# Altium schematic sheet TPS6120x.SchDoc(TPS6120x)
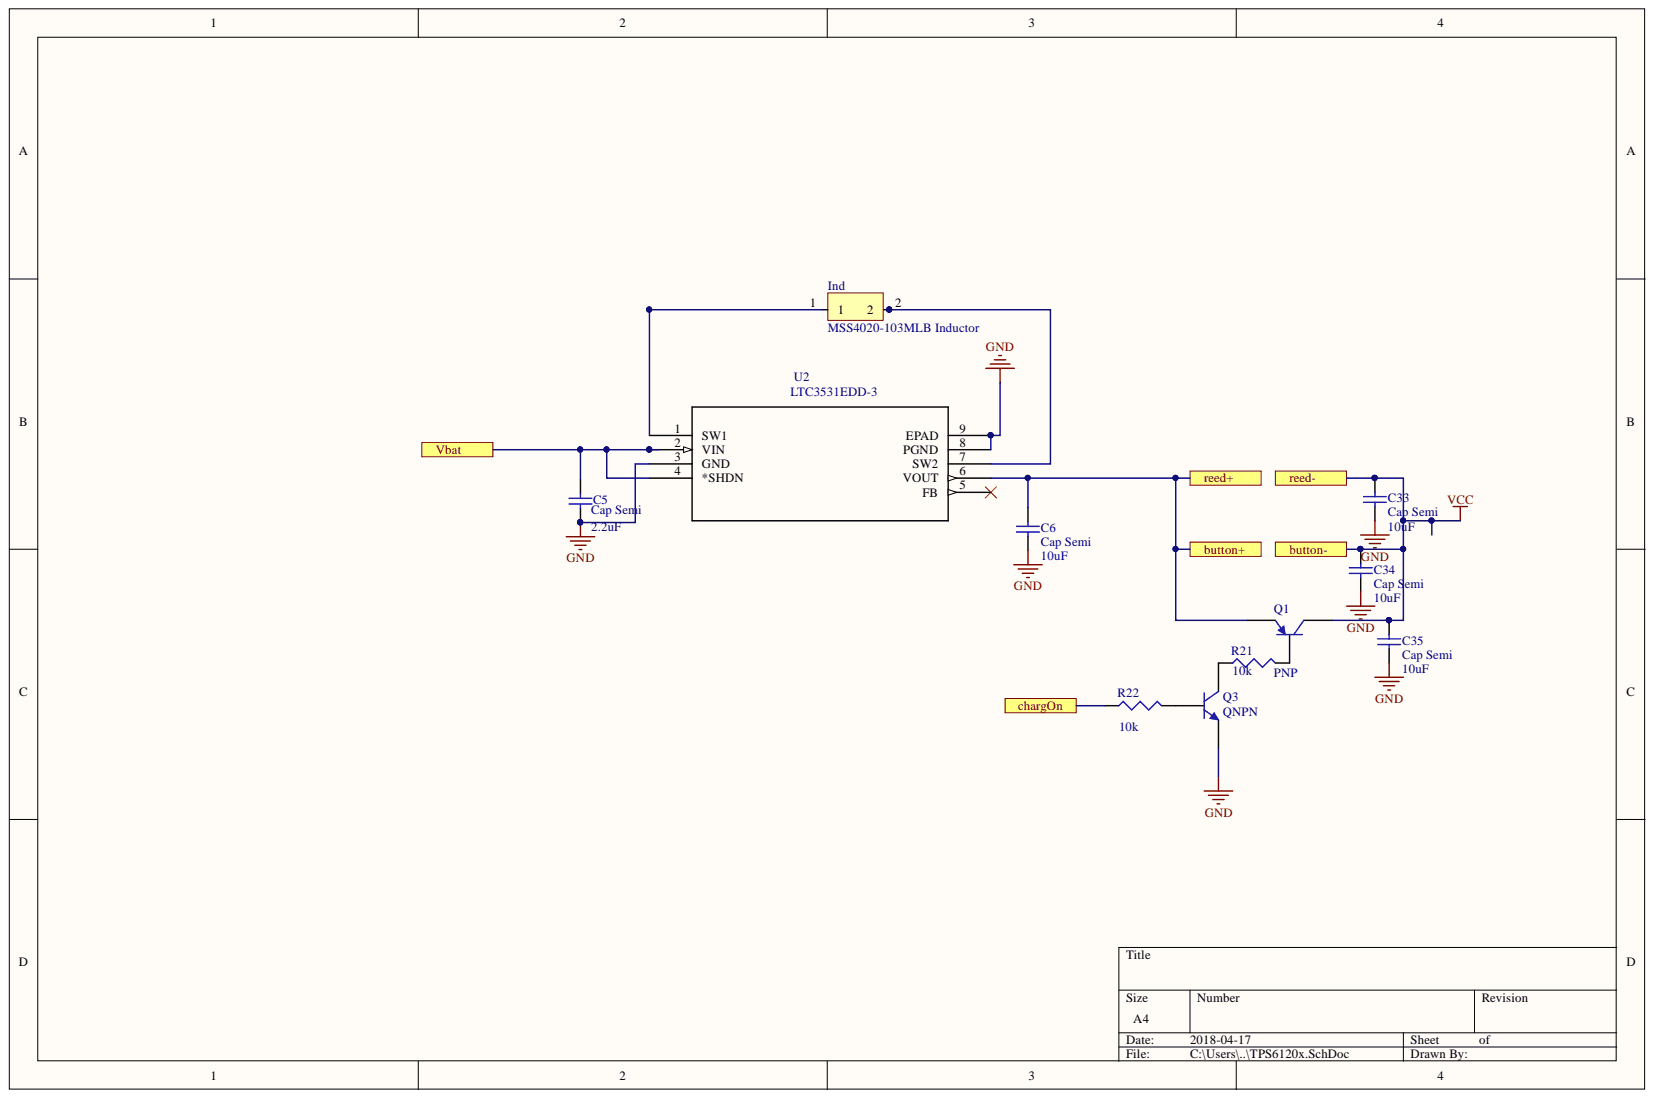
[diagram p0: TPS6120x.SchDoc]

## Parts
C5  Cap Semi  value 2.2uF  [1608[0603]]
C6  Cap Semi  value 10uF  [1608[0603]]
C33  Cap Semi  value 10uF  [1608[0603]]
C34  Cap Semi  value 10uF  [1608[0603]]
C35  Cap Semi  value 10uF  [1608[0603]]
Ind  MSS4020-103MLB Inductor  [MSS4020-103MLB]
Q1  PNP  [SOT-23B_N]
Q3  QNPN  [SOT-23B_N]
R21  value 10k  [J1-0603]  (hidden comment: Res3)
R22  value 10k  [J1-0603]  (hidden comment: Res3)
U2  LTC3531EDD-3  [LTC3531EDD-3]

## Ports
button+, button-, chargOn, reed+, reed-, Vbat

## Nets
GND: C5.1 C6.1 C33.1 C34.1 C35.1 Q3.3 U2.3(GND) U2.8(PGND) U2.9(EPAD)
VCC: C33.2 C34.2 C35.2 Q1.1
chargOn: R22.1 ; port chargOn
Vbat: C5.2 U2.2(VIN) U2.4(*SHDN) ; port Vbat
NetC6_2: C6.2 Q1.3 U2.6(VOUT)
NetInd_1: Ind.1 U2.1(SW1)
NetInd_2: Ind.2 U2.7(SW2)
NetQ1_2: Q1.2 R21.2
NetQ3_1: Q3.1 R21.1
NetQ3_2: Q3.2 R22.2

Not connected: U2 5(FB)

## Library data of the parts
C5 C6 C33-C35 = Cap Semi: Capacitor (Semiconductor SIM Model); Simulation: CAP; Signal Integrity: Cap Semi; Published: 8-Jun-2000; PackageReference: C1206; PackageDescription: Chip Capacitor; Library Name: Miscellaneous Devices.IntLib; LatestRevisionNote: IPC-7351 Footprint Added.; LatestRevisionDate: 29-May-2009; Component Kind: Standard; Publisher: Altium Limited
Q1 = PNP: PNP Bipolar Transistor; Simulation: PNP; Signal Integrity: PNP; Published: 8-Jun-2000; PackageVersion: Aug-1999; PackageReference: SOT-23B; PackageDescription: 3-Pin SOT-23 Package 0.95 mm Pitch, 2.4 mm Lead Span; Library Name: Miscellaneous Devices.IntLib; LatestRevisionNote: IPC-7351 Footprint Added.; LatestRevisionDate: 29-May-2009; Component Kind: Standard; Publisher: Altium Limited
Q3 = QNPN: NPN Bipolar Transistor; Simulation: QNPN; Signal Integrity: NPN; Published: 8-Jun-2000; PackageVersion: Aug-1999; PackageReference: SOT-23B; PackageDescription: 3-Pin SOT-23 Package 0.95 mm Pitch, 2.4 mm Lead Span; Library Name: Miscellaneous Devices.IntLib; LatestRevisionNote: IPC-7351 Footprint Added.; LatestRevisionDate: 29-May-2009; Component Kind: Standard; Publisher: Altium Limited
R21 R22 = Res3: Resistor; Simulation: RESISTOR; Signal Integrity: Res; Published: 8-Jun-2000; PackageReference: J1-0603; PackageDescription: Chip Resistor; Library Name: Miscellaneous Devices.IntLib; LatestRevisionNote: IPC-7351 Footprint Added.; LatestRevisionDate: 29-May-2009; Component Kind: Standard; Publisher: Altium Limited
U2 = LTC3531EDD-3: LTC3531 - 200mA Buck-Boost Synchronous DC/DC Converters; MF: Linear Technology; SnapEDA_Link: https://www.snapeda.com/parts/LTC3531EDD-3/Linear%20Technology/view-part/?ref=snap; Price: None; Package: None; MP: LTC3531EDD-3; Component Kind: Standard; Availability: Unavailable; Library Name: HGCDLib.SchLib
Ind = MSS4020-103MLB Inductor: Component Kind: Standard; Library Name: HGCDLibrary2.IntLib
